# Altium schematic sheet Keyboard.SchDoc(Keyboard)
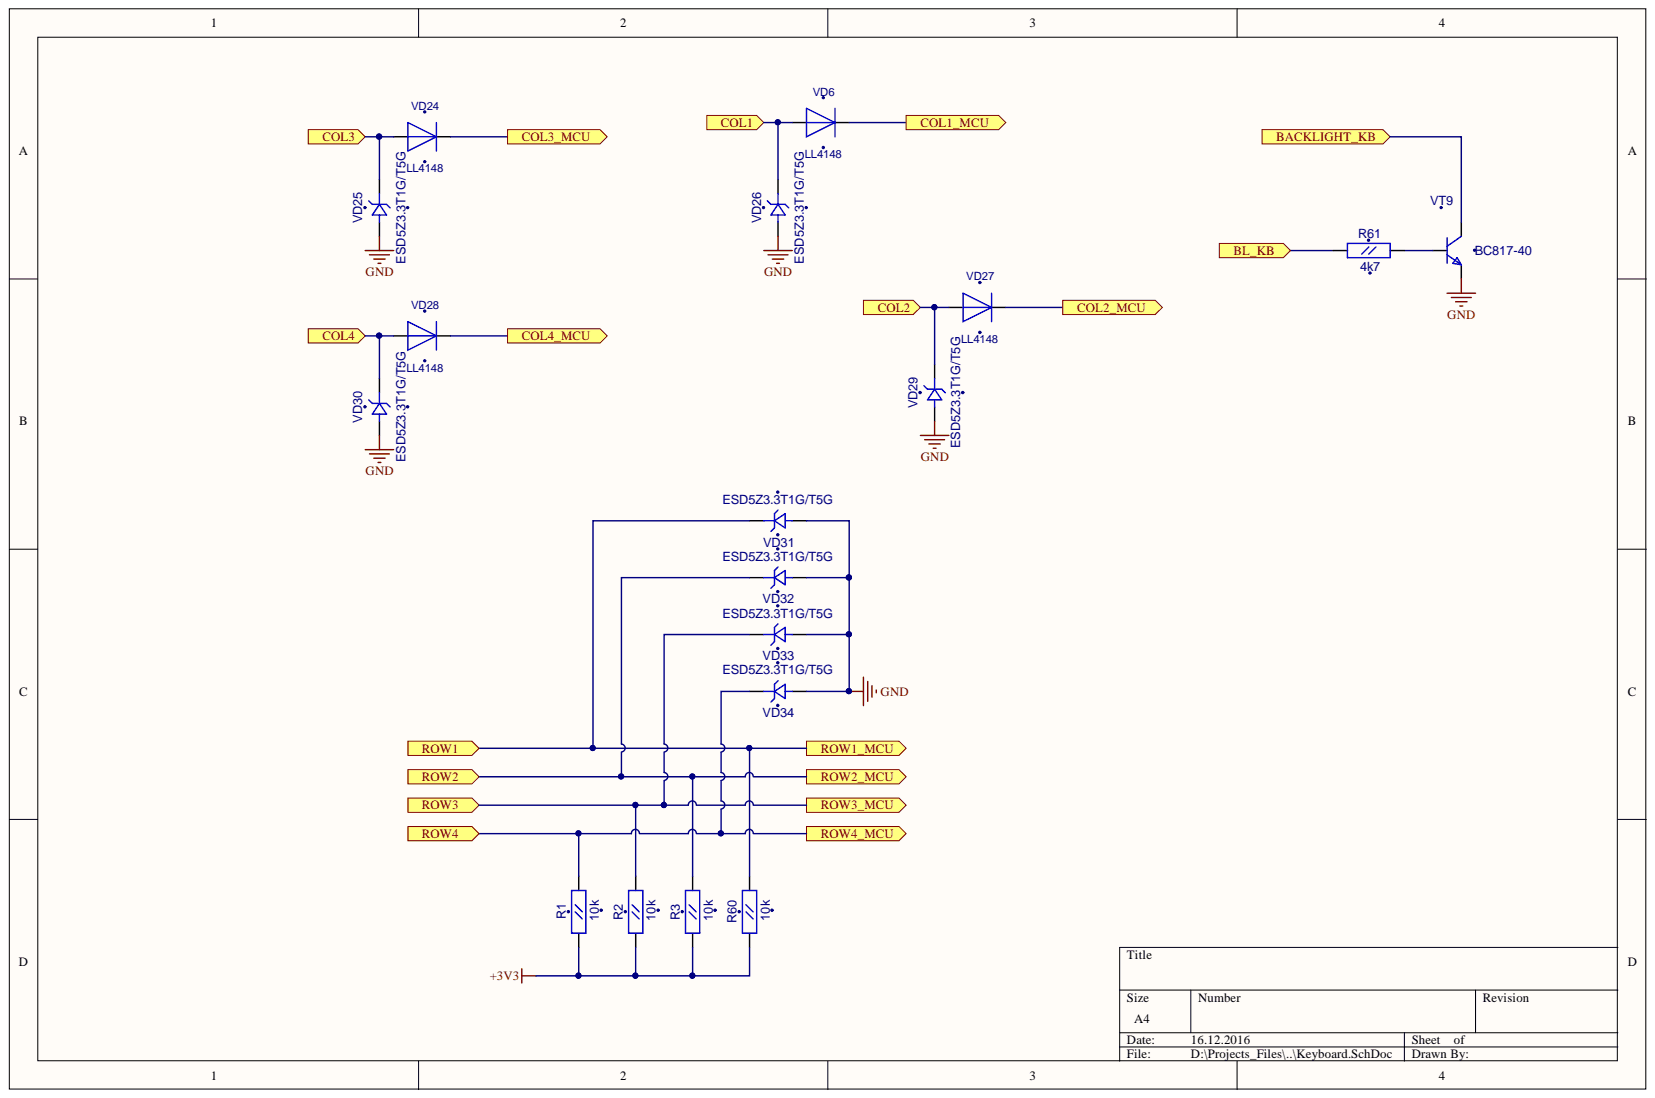
[diagram p0: Keyboard.SchDoc]

## Parts
R1  10k  [RC0603]
R2  10k  [RC0603]
R3  10k  [RC0603]
R60  10k  [RC0603]
R61  4k7  [RC0603]
VD6  LL4148  [SOD-80]
VD24  LL4148  [SOD-80]
VD25  ESD5Z3.3T1G/T5G  [SOD-523]
VD26  ESD5Z3.3T1G/T5G  [SOD-523]
VD27  LL4148  [SOD-80]
VD28  LL4148  [SOD-80]
VD29  ESD5Z3.3T1G/T5G  [SOD-523]
VD30  ESD5Z3.3T1G/T5G  [SOD-523]
VD31  ESD5Z3.3T1G/T5G  [SOD-523]
VD32  ESD5Z3.3T1G/T5G  [SOD-523]
VD33  ESD5Z3.3T1G/T5G  [SOD-523]
VD34  ESD5Z3.3T1G/T5G  [SOD-523]
VT9  BC817-40  [SOT-23(123)]

## Ports
BACKLIGHT_KB, BL_KB, COL1, COL1_MCU, COL2, COL2_MCU, COL3, COL3_MCU, COL4, COL4_MCU, ROW1, ROW1_MCU, ROW2, ROW2_MCU, ROW3, ROW3_MCU, ROW4, ROW4_MCU

## Nets
+3V3: R1.1 R2.1 R3.1 R60.1
GND: VD25.1 VD26.1 VD29.1 VD30.1 VD31.1 VD32.1 VD33.1 VD34.1 VT9.2
BACKLIGHT_KB: VT9.3 ; port BACKLIGHT_KB
BL_KB: R61.1 ; port BL_KB
COL1: VD6.1 VD26.2 ; port COL1
COL1_MCU: VD6.2 ; port COL1_MCU
COL2: VD27.1 VD29.2 ; port COL2
COL2_MCU: VD27.2 ; port COL2_MCU
COL3: VD24.1 VD25.2 ; port COL3
COL3_MCU: VD24.2 ; port COL3_MCU
COL4: VD28.1 VD30.2 ; port COL4
COL4_MCU: VD28.2 ; port COL4_MCU
ROW1: R60.2 VD31.2 ; port ROW1, port ROW1_MCU
ROW2: R3.2 VD32.2 ; port ROW2, port ROW2_MCU
ROW3: R2.2 VD33.2 ; port ROW3, port ROW3_MCU
ROW4: R1.2 VD34.2 ; port ROW4, port ROW4_MCU
NetR61_2: R61.2 VT9.1

## Library data of the parts
R1-R3 R60 R61 = RC0603: Component Kind: Standard; Description: RC0603; Library Name: gprs_modem_2.SchLib
  R1-R3 R60: Value: 10k
  R61: Value: 4k7
VD25 VD26 VD29-VD34 = ESD5Z: Supressor; Component Kind: Standard; Value: ESD5Z3.3T1G/T5G; Library Name: gprs_modem_2.SchLib
VT9 = BC846BLT1: NPN 500ma transistor; ComponentField7: Published 29-Sep-2000; Component Kind: Standard; OriginalName: BC846BLT1; Simulation: BC846BLT1; Value: BC817-40; Library Name: gprs_modem_2.SchLib
VD6 VD24 VD27 VD28 = LL4148: Component Kind: Standard; Description: LL4148; Library Name: gprs_modem_2.SchLib
